# Altium schematic sheet FPGA.SchDoc
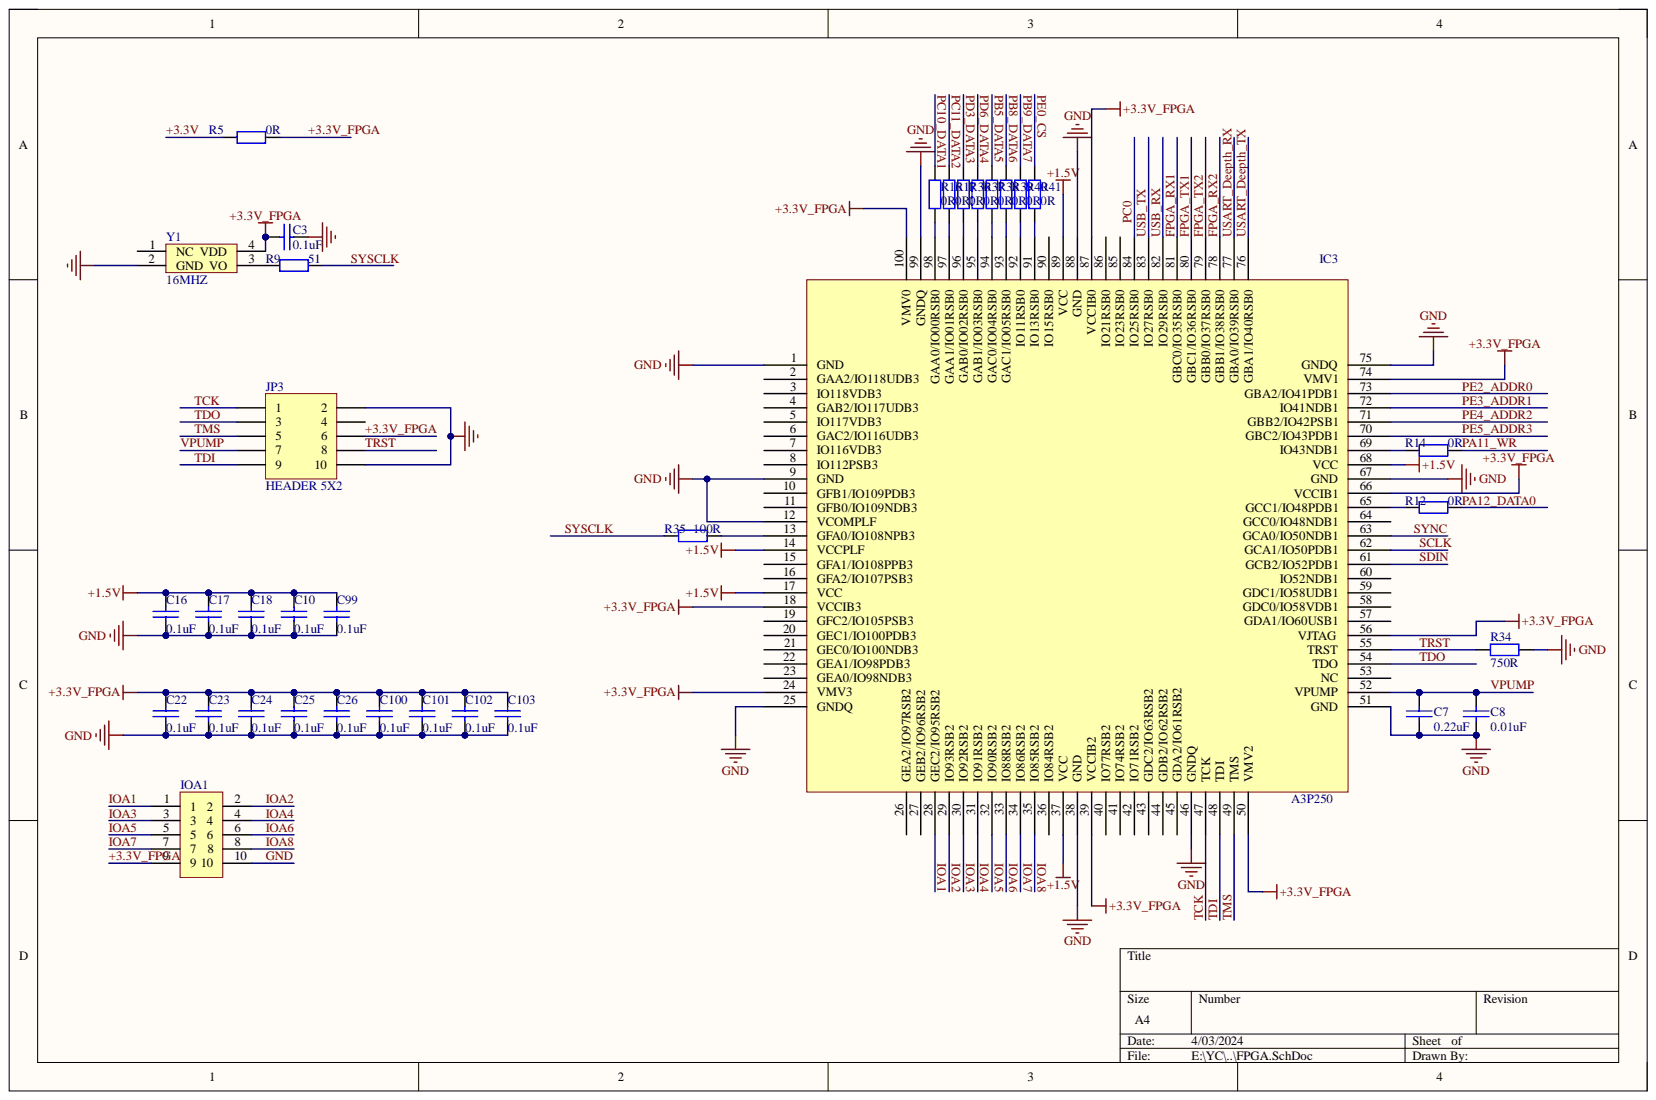
[diagram p0: FPGA.SchDoc]

## Parts
C3  0.1uF  [0805C]
C7  0.22uF  [0805C]
C8  0.01uF  [0805C]
C10  0.1uF  [0805C]
C16  0.1uF  [0805C]
C17  0.1uF  [0805C]
C18  0.1uF  [0805C]
C22  0.1uF  [0805C]
C23  0.1uF  [0805C]
C24  0.1uF  [0805C]
C25  0.1uF  [0805C]
C26  0.1uF  [0805C]
C99  0.1uF  [0805C]
C100  0.1uF  [0805C]
C101  0.1uF  [0805C]
C102  0.1uF  [0805C]
C103  0.1uF  [0805C]
IC3  A3P250  [TQFP100]
IOA1  HEADER 5X2  [IDC10-Z]
JP3  HEADER 5X2  [IDC10_2.54]
R5  0R  [0805]
R9  51  [0805]
R12  0R  [0805]
R14  0R  [0805]
R16  0R  [0805]
R17  0R  [0805]
R34  750R  [0805]
R35  100R  [0805]
R36  0R  [0805]
R37  0R  [0805]
R38  0R  [0805]
R39  0R  [0805]
R40  0R  [0805]
R41  0R  [0805]
Y1  16MHZ  [OSC_RECTANGAL]

## Nets
+1.5V: C10.2 C16.2 C17.2 C18.2 C99.2 IC3.14(VCCPLF) IC3.17(VCC) IC3.37(VCC) IC3.68(VCC) IC3.89(VCC)
+3.3V: R5.2
+3.3V_FPGA: C3.1 C22.2 C23.2 C24.2 C25.2 C26.2 C100.2 C101.2 C102.2 C103.2 IC3.18(VCCIB3) IC3.24(VMV3) IC3.39(VCCIB2) IC3.50(VMV2) IC3.56(VJTAG) IC3.66(VCCIB1) IC3.74(VMV1) IC3.87(VCCIB0) IC3.100(VMV0) IOA1.9 JP3.6 R5.1 Y1.4(VDD)
FPGA_RX1: IC3.81(GBC0/IO35RSB0)
FPGA_RX2: IC3.78(GBB1/IO38RSB0)
FPGA_TX1: IC3.80(GBC1/IO36RSB0)
FPGA_TX2: IC3.79(GBB0/IO37RSB0)
GND: C3.2 C7.2 C8.2 C10.1 C16.1 C17.1 C18.1 C22.1 C23.1 C24.1 C25.1 C26.1 C99.1 C100.1 C101.1 C102.1 C103.1 IC3.1(GND) IC3.9(GND) IC3.12(VCOMPLF) IC3.25(GNDQ) IC3.38(GND) IC3.46(GNDQ) IC3.51(GND) IC3.67(GND) IC3.75(GNDQ) IC3.88(GND) IC3.99(GNDQ) IOA1.10 JP3.2 JP3.10 R34.2 Y1.2(GND)
IOA1: IC3.28(GEC2/IO95RSB2) IOA1.1
IOA2: IC3.29(IO93RSB2) IOA1.2
IOA3: IC3.30(IO92RSB2) IOA1.3
IOA4: IC3.31(IO91RSB2) IOA1.4
IOA5: IC3.32(IO90RSB2) IOA1.5
IOA6: IC3.33(IO88RSB2) IOA1.6
IOA7: IC3.34(IO86RSB2) IOA1.7
IOA8: IC3.35(IO85RSB2) IOA1.8
PA11_WR: R14.2
PA12_DATA0: R12.1
PB5_DATA5: R38.2
PB8_DATA6: R39.2
PB9_DATA7: R40.2
PC0: IC3.84(IO25RSB0)
PC10_DATA1: R16.1
PC11_DATA2: R17.2
PD3_DATA3: R36.2
PD6_DATA4: R37.2
PE0_CS: R41.1
PE2_ADDR0: IC3.73(GBA2/IO41PDB1)
PE3_ADDR1: IC3.72(IO41NDB1)
PE4_ADDR2: IC3.71(GBB2/IO42PSB1)
PE5_ADDR3: IC3.70(GBC2/IO43PDB1)
SCLK: IC3.62(GCA1/IO50PDB1)
SDIN: IC3.61(GCB2/IO52PDB1)
SYNC: IC3.63(GCA0/IO50NDB1)
SYSCLK: R9.2 R35.1
TCK: IC3.47(TCK) JP3.1
TDI: IC3.48(TDI) JP3.9
TDO: IC3.54(TDO) JP3.3
TMS: IC3.49(TMS) JP3.5
TRST: IC3.55(TRST) JP3.8 R34.1
USART_Deepth_RX: IC3.77(GBA0/IO39RSB0)
USART_Deepth_TX: IC3.76(GBA1/IO40RSB0)
USB_RX: IC3.82(IO29RSB0)
USB_TX: IC3.83(IO27RSB0)
VPUMP: C7.1 C8.1 IC3.52(VPUMP) JP3.7
NetIC3_13: IC3.13(GFA0/IO108NPB3) R35.2
NetIC3_65: IC3.65(GCC1/IO48PDB1) R12.2
NetIC3_69: IC3.69(IO43NDB1) R14.1
NetIC3_91: IC3.91(IO13RSB0) R41.2
NetIC3_92: IC3.92(IO11RSB0) R40.1
NetIC3_93: IC3.93(GAC1/IO05RSB0) R39.1
NetIC3_94: IC3.94(GAC0/IO04RSB0) R38.1
NetIC3_95: IC3.95(GAB1/IO03RSB0) R37.1
NetIC3_96: IC3.96(GAB0/IO02RSB0) R36.1
NetIC3_97: IC3.97(GAA1/IO01RSB0) R17.1
NetIC3_98: IC3.98(GAA0/IO00RSB0) R16.2
NetR9_1: R9.1 Y1.3(VO)

Not connected: IC3 2(GAA2/IO118UDB3) 3(IO118VDB3) 4(GAB2/IO117UDB3) 5(IO117VDB3) 6(GAC2/IO116UDB3) 7(IO116VDB3) 8(IO112PSB3) 10(GFB1/IO109PDB3) 11(GFB0/IO109NDB3) 15(GFA1/IO108PPB3) 16(GFA2/IO107PSB3) 19(GFC2/IO105PSB3) 20(GEC1/IO100PDB3) 21(GEC0/IO100NDB3) 22(GEA1/IO98PDB3) 23(GEA0/IO98NDB3) 26(GEA2/IO97RSB2) 27(GEB2/IO96RSB2) 36(IO84RSB2) 40(IO77RSB2) 41(IO74RSB2) 42(IO71RSB2) 43(GDC2/IO63RSB2) 44(GDB2/IO62RSB2) 45(GDA2/IO61RSB2) 53(NC) 57(GDA1/IO60USB1) 58(GDC0/IO58VDB1) 59(GDC1/IO58UDB1) 60(IO52NDB1) 64(GCC0/IO48NDB1) 85(IO23RSB0) 86(IO21RSB0) 90(IO15RSB0); JP3 4; Y1 1(NC)

## Library data of the parts
C3 C7 C8 C10 C16-C18 C22-C26 C99-C103 = CAP: Capacitor; Component Kind: Standard
IC3 = VQFP: Component Kind: Standard
IOA1 = HEADER: Component Kind: Standard; Library Name: 杂元件库.SCHLIB
JP3 = HEADER 5X2: 排针/排母; Component Kind: Standard; Library Name: TR_SCHLIB.SchLib
R5 R9 = Res2: Resistor; Component Kind: Standard; LatestRevisionDate: 17-Jul-2002; LatestRevisionNote: Re-released for DXP Platform.; Library Name: Miscellaneous Devices.IntLib; PackageDescription: Axial Device, Thru-Hole; 2 Leads; 0.4 in Pin Spacing; PackageReference: AXIAL-0.4; Published: 8-Jun-2000; Signal Integrity: Res; Simulation: RESISTOR; Publisher: Altium Limited
  R5: Value: 0R
  R9: Value: 51
R12 R14 R16 R17 R34-R41 = RES_0603: Component Kind: Standard; Library Name: TR_SCHLIB.SchLib
Y1 = OSC: Component Kind: Standard; Library Name: Previous Backup of Schlib1.Lib
